# Altium schematic sheet OpenArm3in1.SchDoc
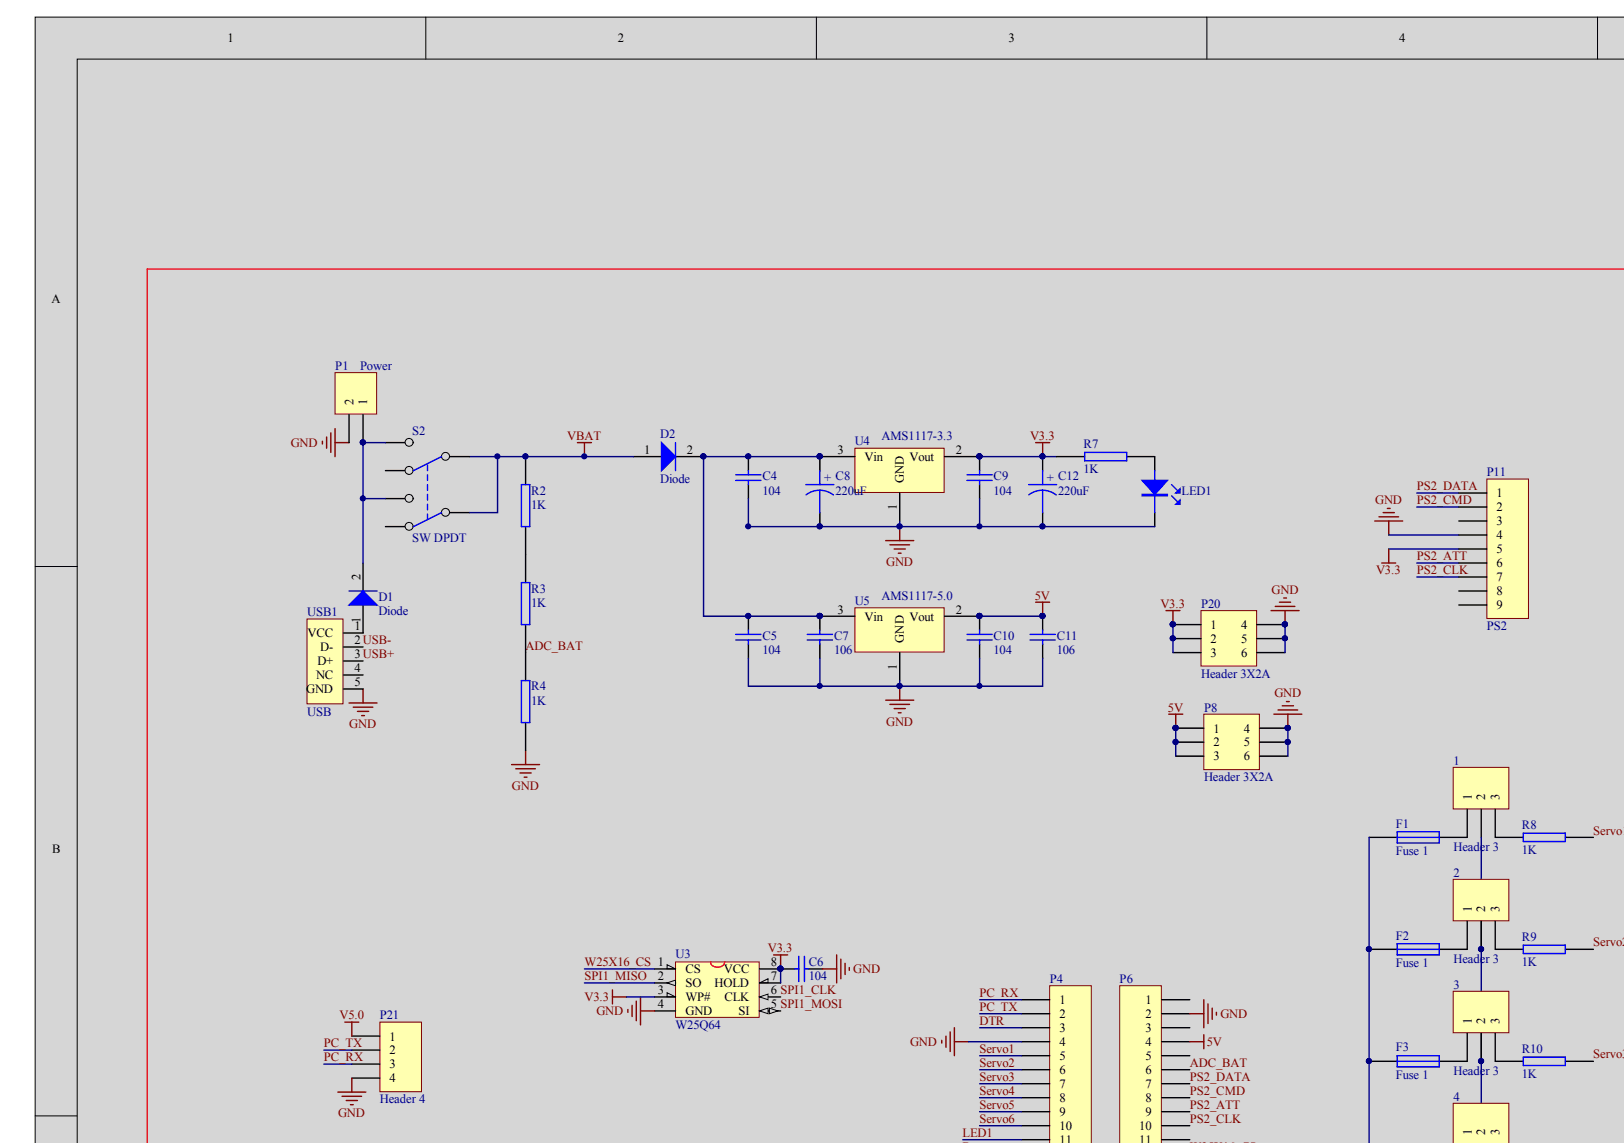
[diagram p0: OpenArm3in1.SchDoc, top left]
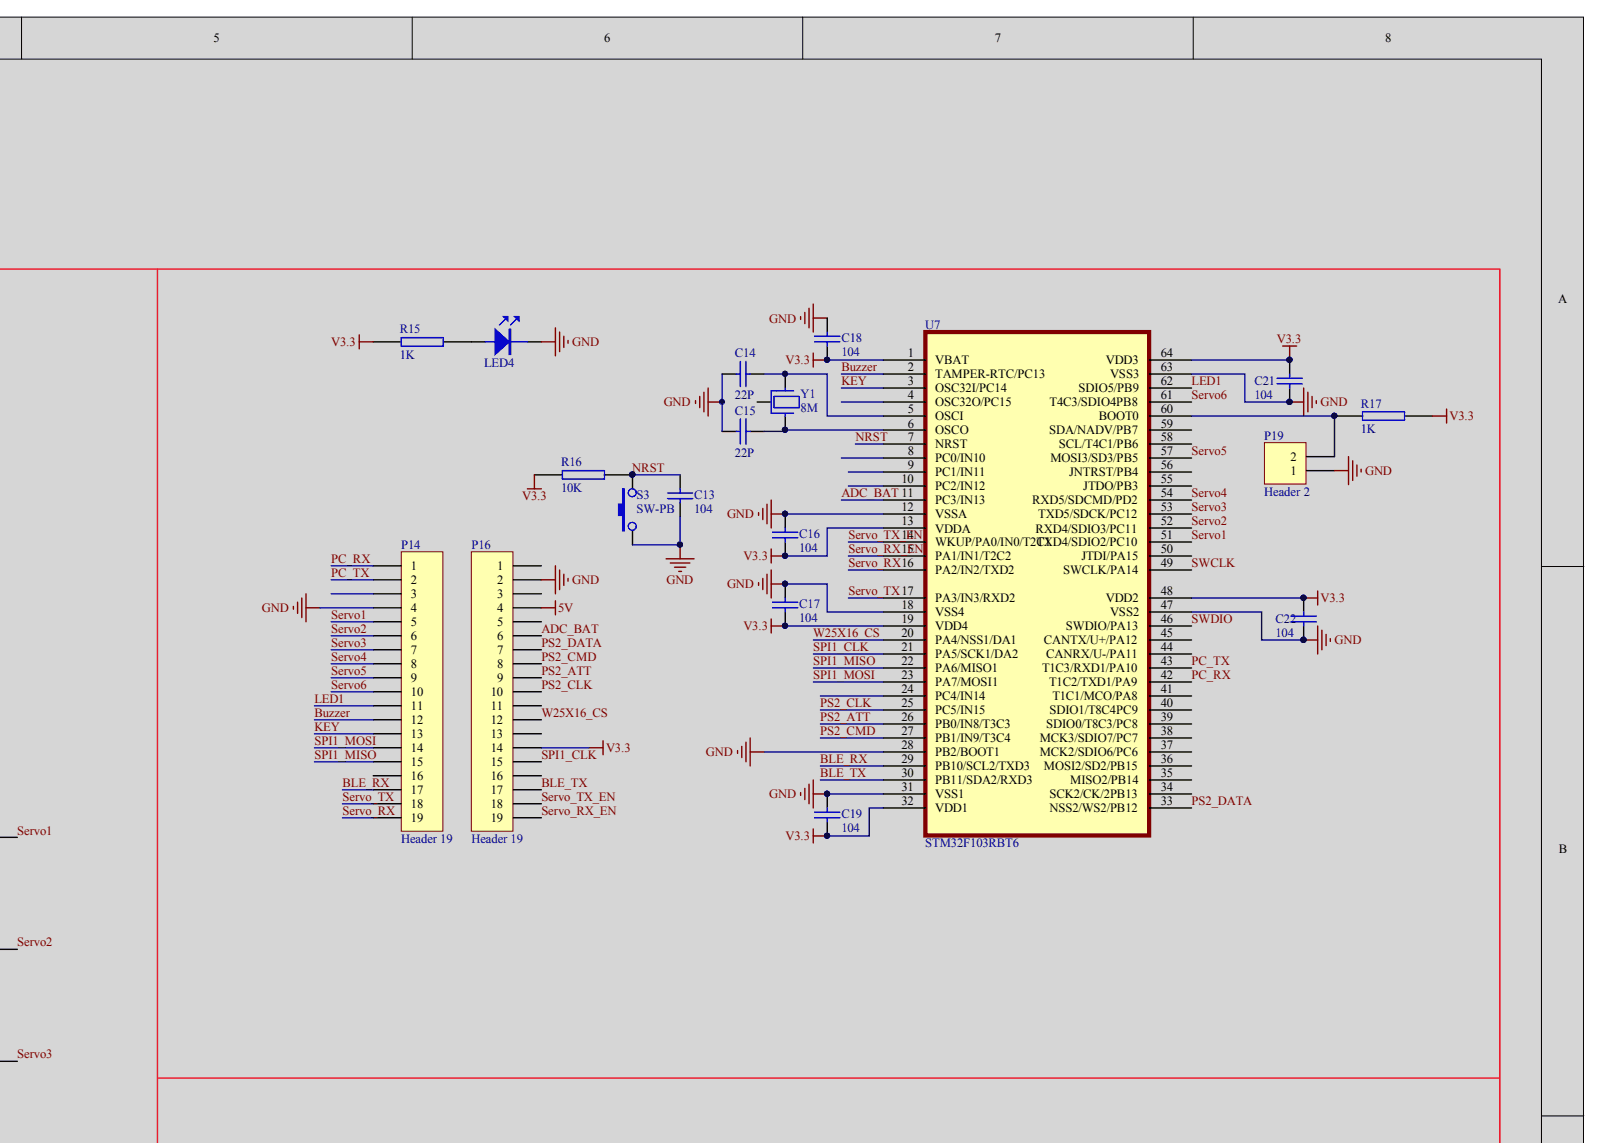
[diagram p1: OpenArm3in1.SchDoc, top right]
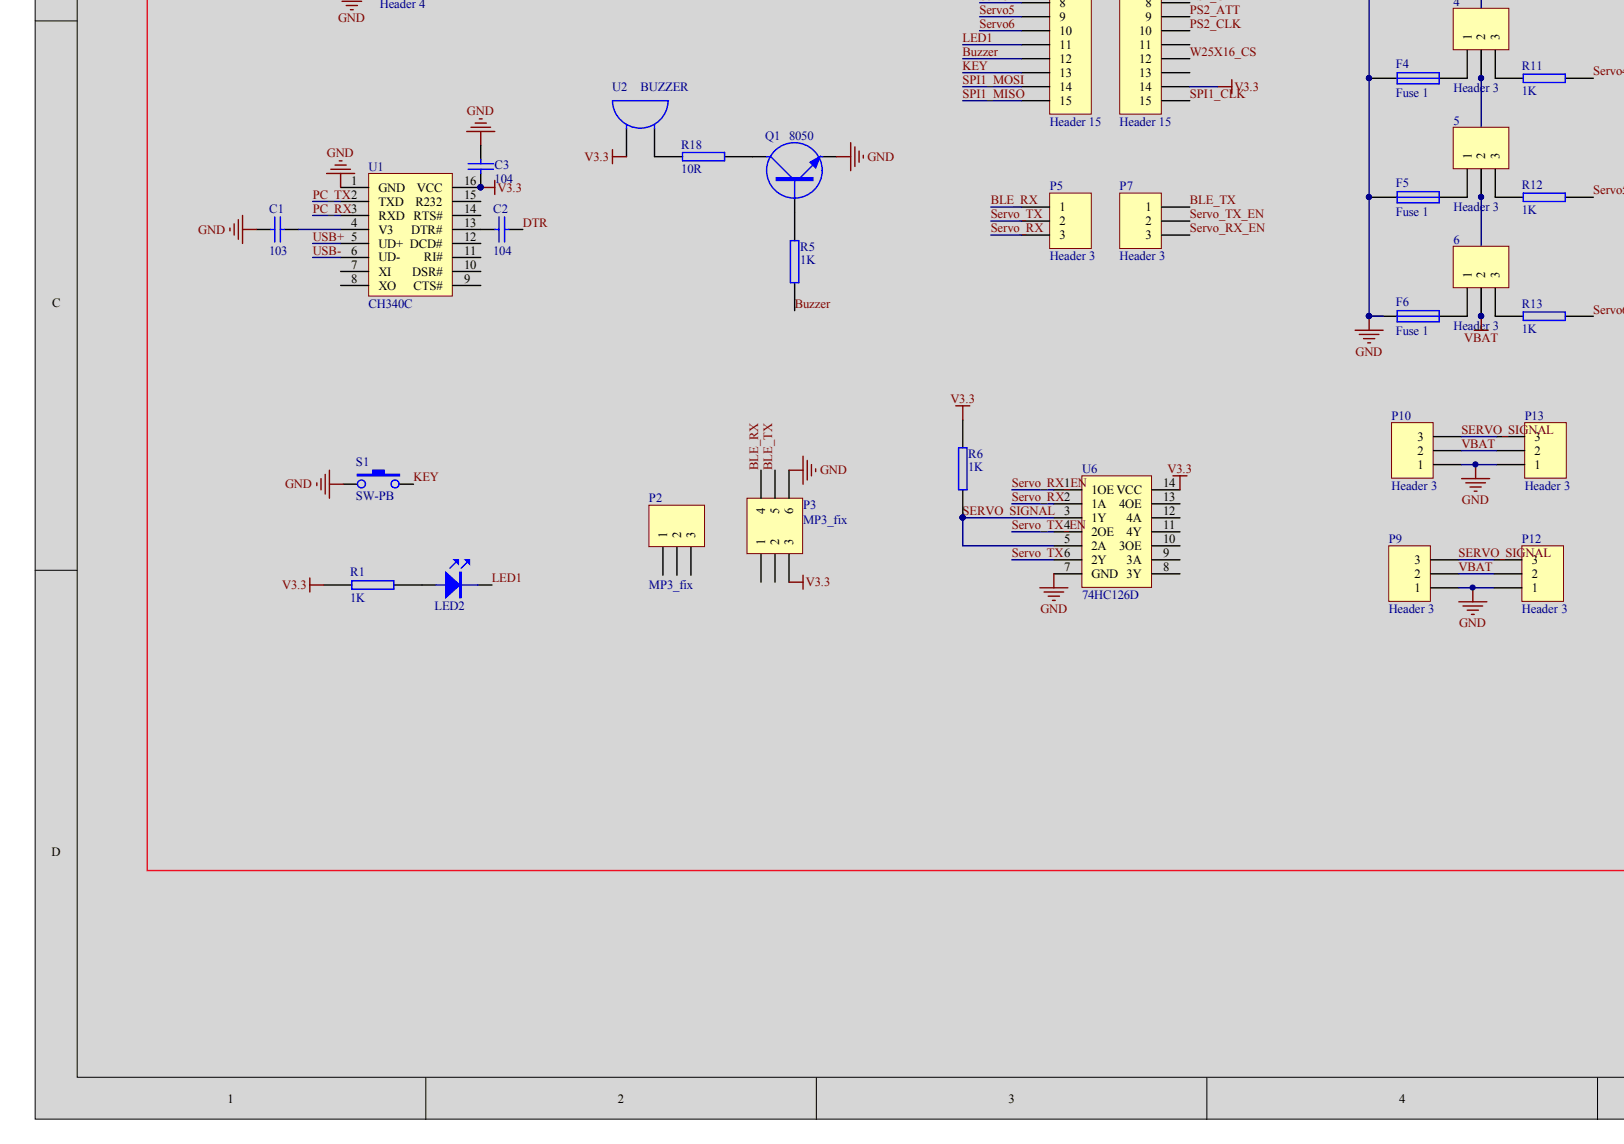
[diagram p2: OpenArm3in1.SchDoc, bottom left]
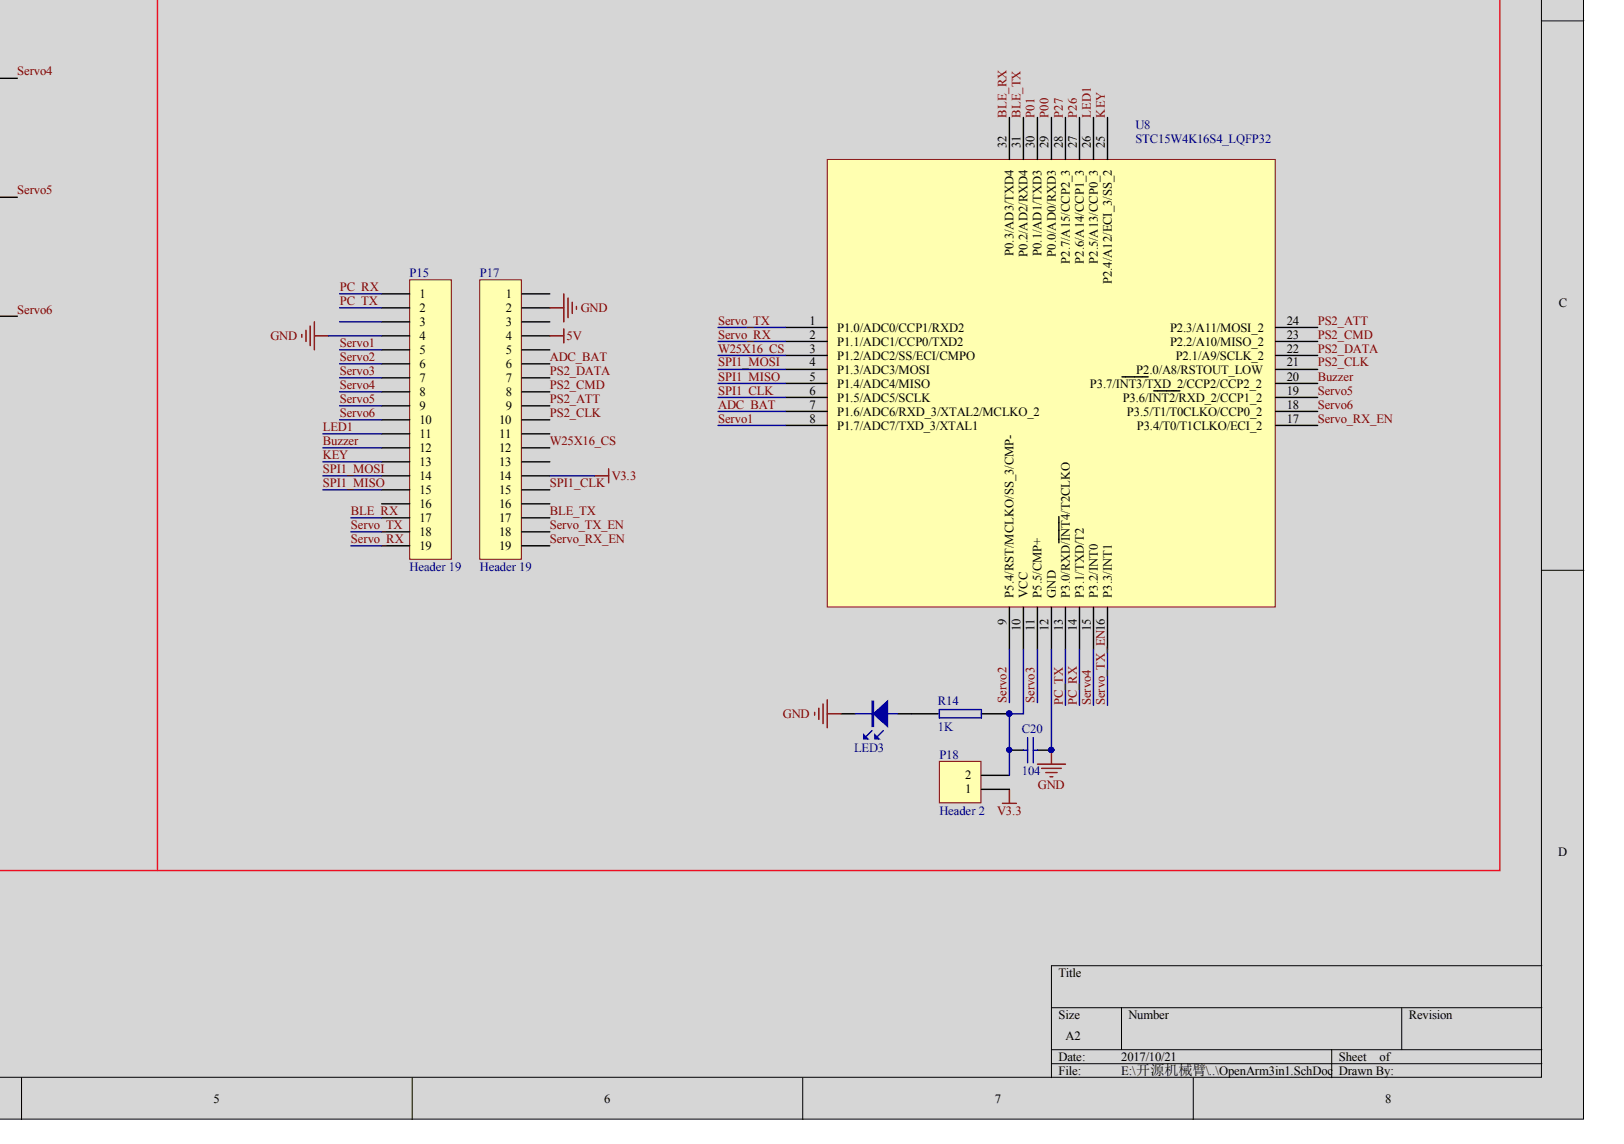
[diagram p3: OpenArm3in1.SchDoc, bottom right]

## Parts
1  Header 3
2  Header 3
3  Header 3
4  Header 3
5  Header 3
6  Header 3
C1  103
C2  104
C3  104
C4  104
C5  104
C6  104
C7  106
C8  220uF
C9  104
C10  104
C11  106
C12  220uF
C13  104
C14  22P
C15  22P
C16  104
C17  104
C18  104
C19  104
C20  104
C21  104
C22  104
D1  Diode
D2  Diode
F1  Fuse 1
F2  Fuse 1
F3  Fuse 1
F4  Fuse 1
F5  Fuse 1
F6  Fuse 1
LED1  LED
LED2  LED
LED3  LED
LED4  LED
P1  Power
P2  MP3_fix
P3  MP3_fix
P4  Header 15
P5  Header 3
P6  Header 15
P7  Header 3
P8  Header 3X2A
P9  Header 3
P10  Header 3
P11  PS2
P12  Header 3
P13  Header 3
P14  Header 19
P15  Header 19
P16  Header 19
P17  Header 19
P18  Header 2
P19  Header 2
P20  Header 3X2A
P21  Header 4
Q1  8050
R1  1K
R2  1K
R3  1K
R4  1K
R5  1K
R6  1K
R7  1K
R8  1K
R9  1K
R10  1K
R11  1K
R12  1K
R13  1K
R14  1K
R15  1K
R16  10K
R17  1K
R18  10R
S1  SW-PB
S2  SW DPDT
S3  SW-PB
U1  CH340C
U2  BUZZER
U3  W25Q64
U4  AMS1117-3.3
U5  AMS1117-5.0
U6  74HC126D
U7  STM32F103RBT6
U8  STC15W4K16S4_LQFP32
USB1  USB
Y1  8M

## Nets
5V: C10.1 C11.1 P6.4 P8.1 P8.2 P8.3 P16.4 P17.4 U5.2
GND: C1.2 C3.1 C4.2 C5.2 C6.2 C7.2 C8.2 C9.2 C10.2 C11.2 C12.2 C13.2 C14.1 C15.1 C16.1 C17.1 C18.1 C19.1 C20.2 C21.2 C22.2 F1.1 F2.1 F3.1 F4.1 F5.1 F6.1 LED1.2 LED3.2 LED4.2 P1.2 P3.6 P4.4 P6.2 P8.4 P8.5 P8.6 P9.1 P10.1 P11.4 P12.1 P13.1 P14.4 P15.4 P16.2 P17.2 P19.1 P20.4 P20.5 P20.6 P21.4 Q1.2 R4.1 S1.1 S3.1 U1.1(GND) U3.4(GND) U4.1 U5.1 U6.7(GND) U7.12(VSSA) U7.18(VSS4) U7.28(PB2/BOOT1) U7.31(VSS1) U7.47(VSS2) U7.63(VSS3) U8.12(GND) USB1.5(GND) USB1.6 USB1.7 USB1.8 USB1.9
V3.3: C3.2 C6.1 C9.1 C12.1 C16.2 C17.2 C18.2 C19.2 C21.1 C22.1 P3.3 P6.14 P11.5 P16.14 P17.14 P18.1 P20.1 P20.2 P20.3 R1.1 R6.2 R7.1 R15.1 R16.1 R17.1 U1.16(VCC) U2.1 U3.3(WP#) U3.7(HOLD) U3.8(VCC) U4.2 U6.14(VCC) U7.1(VBAT) U7.13(VDDA) U7.19(VDD4) U7.32(VDD1) U7.48(VDD2) U7.64(VDD3)
V5.0: P21.1
ADC_BAT: P6.6 P16.6 P17.6 R3.1 R4.2 U7.11(PC3/IN13) U8.7(P1.6/ADC6/RXD_3/XTAL2/MCLKO_2)
BLE_RX: P3.4 P5.1 P14.17 P15.17 U7.29(PB10/SCL2/TXD3) U8.32(P0.3/AD3/TXD4)
BLE_TX: P3.5 P7.1 P16.17 P17.17 U7.30(PB11/SDA2/RXD3) U8.31(P0.2/AD2/RXD4)
BUZZER: P4.12 P14.12 P15.12 R5.1 U7.2(TAMPER-RTC/PC13) U8.20(P3.7/INT3/TXD_2/CCP2/CCP2_2)
DTR: C2.1 P4.3
KEY: P4.13 P14.13 P15.13 S1.2 U7.3(OSC32I/PC14) U8.25(P2.4/A12/ECI_3/SS_2)
LED1: LED2.2 P4.11 P14.11 P15.11 U7.62(SDIO5/PB9) U8.26(P2.5/A13/CCP0_3)
NRST: C13.1 R16.2 S3.2 U7.7(NRST)
P00: U8.29(P0.0/AD0/RXD3)
P01: U8.30(P0.1/AD1/TXD3)
P26: U8.27(P2.6/A14/CCP1_3)
P27: U8.28(P2.7/A15/CCP2_3)
PC_RX: P4.1 P14.1 P15.1 P21.3 U1.3(RXD) U7.42(T1C2/TXD1/PA9) U8.14(P3.1/TXD/T2)
PC_TX: P4.2 P14.2 P15.2 P21.2 U1.2(TXD) U7.43(T1C3/RXD1/PA10) U8.13(P3.0/RXD/INT4/T2CLKO)
PS2_ATT: P6.9 P11.6 P16.9 P17.9 U7.26(PB0/IN8/T3C3) U8.24(P2.3/A11/MOSI_2)
PS2_CLK: P6.10 P11.7 P16.10 P17.10 U7.25(PC5/IN15) U8.21(P2.0/A8/RSTOUT_LOW)
PS2_CMD: P6.8 P11.2 P16.8 P17.8 U7.27(PB1/IN9/T3C4) U8.23(P2.2/A10/MISO_2)
PS2_DATA: P6.7 P11.1 P16.7 P17.7 U7.33(NSS2/WS2/PB12) U8.22(P2.1/A9/SCLK_2)
SERVO1: P4.5 P14.5 P15.5 R8.2 U7.51(TXD4/SDIO2/PC10) U8.8(P1.7/ADC7/TXD_3/XTAL1)
SERVO2: P4.6 P14.6 P15.6 R9.2 U7.52(RXD4/SDIO3/PC11) U8.9(P5.4/RST/MCLKO/SS_3/CMP-)
SERVO3: P4.7 P14.7 P15.7 R10.2 U7.53(TXD5/SDCK/PC12) U8.11(P5.5/CMP+)
SERVO4: P4.8 P14.8 P15.8 R11.2 U7.54(RXD5/SDCMD/PD2) U8.15(P3.2/INT0)
SERVO5: P4.9 P14.9 P15.9 R12.2 U7.57(MOSI3/SD3/PB5) U8.19(P3.6/INT2/RXD_2/CCP1_2)
SERVO6: P4.10 P14.10 P15.10 R13.2 U7.61(T4C3/SDIO4PB8) U8.18(P3.5/T1/T0CLKO/CCP0_2)
SERVO_RX: P5.3 P14.19 P15.19 U6.2(1A) U7.16(PA2/IN2/TXD2) U8.2(P1.1/ADC1/CCP0/TXD2)
SERVO_RX_EN: P7.3 P16.19 P17.19 U6.1(1OE) U7.15(PA1/IN1/T2C2) U8.17(P3.4/T0/T1CLKO/ECI_2)
SERVO_SIGNAL: P9.3 P10.3 P12.3 P13.3 R6.1 U6.3(1Y) U6.5(2A)
SERVO_TX: P5.2 P14.18 P15.18 U6.6(2Y) U7.17(PA3/IN3/RXD2) U8.1(P1.0/ADC0/CCP1/RXD2)
SERVO_TX_EN: P7.2 P16.18 P17.18 U6.4(2OE) U7.14(WKUP/PA0/IN0/T2C1) U8.16(P3.3/INT1)
SPI1_CLK: P6.15 P16.15 P17.15 U3.6(CLK) U7.21(PA5/SCK1/DA2) U8.6(P1.5/ADC5/SCLK)
SPI1_MISO: P4.15 P14.15 P15.15 U3.2(SO) U7.22(PA6/MISO1) U8.5(P1.4/ADC4/MISO)
SPI1_MOSI: P4.14 P14.14 P15.14 U3.5(SI) U7.23(PA7/MOSI1) U8.4(P1.3/ADC3/MOSI)
SWCLK: U7.49(SWCLK/PA14)
SWDIO: U7.46(SWDIO/PA13)
USB+: U1.5(UD+) USB1.3(D+)
USB-: U1.6(UD-) USB1.2(D-)
VBAT: 1.2 2.2 3.2 4.2 5.2 6.2 D2.1 P9.2 P10.2 P12.2 P13.2 R2.2 S2.2 S2.5
W25X16_CS: P6.12 P16.12 P17.12 U3.1(CS) U7.20(PA4/NSS1/DA1) U8.3(P1.2/ADC2/SS/ECI/CMPO)
Net1_1: 1.1 F1.2
Net1_3: 1.3 R8.1
Net2_1: 2.1 F2.2
Net2_3: 2.3 R9.1
Net3_1: 3.1 F3.2
Net3_3: 3.3 R10.1
Net4_1: 4.1 F4.2
Net4_3: 4.3 R11.1
Net5_1: 5.1 F5.2
Net5_3: 5.3 R12.1
Net6_1: 6.1 F6.2
Net6_3: 6.3 R13.1
NetC1_1: C1.1 U1.4(V3)
NetC2_2: C2.2 U1.13(DTR#)
NetC4_1: C4.1 C5.1 C7.1 C8.1 D2.2 U4.3 U5.3
NetC14_2: C14.2 U7.5(OSCI) Y1.1
NetC15_2: C15.2 U7.6(OSCO) Y1.2
NetC20_1: C20.1 P18.2 R14.1 U8.10(VCC)
NetD1_1: D1.1 USB1.1(VCC)
NetD1_2: D1.2 P1.1 S2.3 S2.6
NetLED1_1: LED1.1 R7.2
NetLED2_1: LED2.1 R1.2
NetLED3_1: LED3.1 R14.2
NetLED4_1: LED4.1 R15.2
NetP19_2: P19.2 R17.2 U7.60(BOOT0)
NetQ1_1: Q1.1 R5.2
NetQ1_3: Q1.3 R18.2
NetR2_1: R2.1 R3.2
NetR18_1: R18.1 U2.2

Not connected: P2 1 2 3; P3 1 2; P6 1 3 5 11 13; P11 3 8 9; P14 3 16; P15 3 16; P16 1 3 5 11 13 16; P17 1 3 5 11 13 16; S2 1 4; U1 7(XI) 8(XO) 9(CTS#) 10(DSR#) 11(RI#) 12(DCD#) 14(RTS#) 15(R232); U6 8(3Y) 9(3A) 10(3OE) 11(4Y) 12(4A) 13(4OE); U7 4(OSC32O/PC15) 8(PC0/IN10) 9(PC1/IN11) 10(PC2/IN12) 24(PC4/IN14) 34(SCK2/CK/2PB13) 35(MISO2/PB14) 36(MOSI2/SD2/PB15) 37(MCK2/SDIO6/PC6) 38(MCK3/SDIO7/PC7) 39(SDIO0/T8C3/PC8) 40(SDIO1/T8C4PC9) 41(T1C1/MCO/PA8) 44(CANRX/U-/PA11) 45(CANTX/U+/PA12) 50(JTDI/PA15) 55(JTDO/PB3) 56(JNTRST/PB4) 58(SCL/T4C1/PB6) 59(SDA/NADV/PB7); USB1 4(NC); Y1 3

## Library data of the parts
1-6 C1-C22 D1 D2 F1-F6 LED1-LED4 P1-P21 Q1 R1-R18 S1-S3 U1-U8 USB1 Y1 = (no symbol name)
  1-6 P1-P21: LatestRevisionDate: 17-Jul-2002; LatestRevisionNote: Re-released for DXP Platform.
  D1 D2: Published: 8-Jun-2000; LatestRevisionDate: 17-Jul-2002; LatestRevisionNote: Re-released for DXP Platform.; PackageVersion: Sep-1996; PackageReference: SMC; PackageDescription: DO-214-AB/SMC; 2 C-Bend Leads; Body 7.9 x 5.9 mm, inc. leads (LxW); Code_JEDEC: DO-214-AB
  F1-F6: Published: 8-Jun-2000; LatestRevisionDate: 17-Jul-2002; LatestRevisionNote: Re-released for DXP Platform.; PackageReference: PIN-W2/E2.8; PackageDescription: Thru-Hole; 2 Leads
  1-6 D1 D2 F1-F6 P1-P21: Publisher: Altium Limited
